# Altium schematic sheet 02-Power.SchDoc
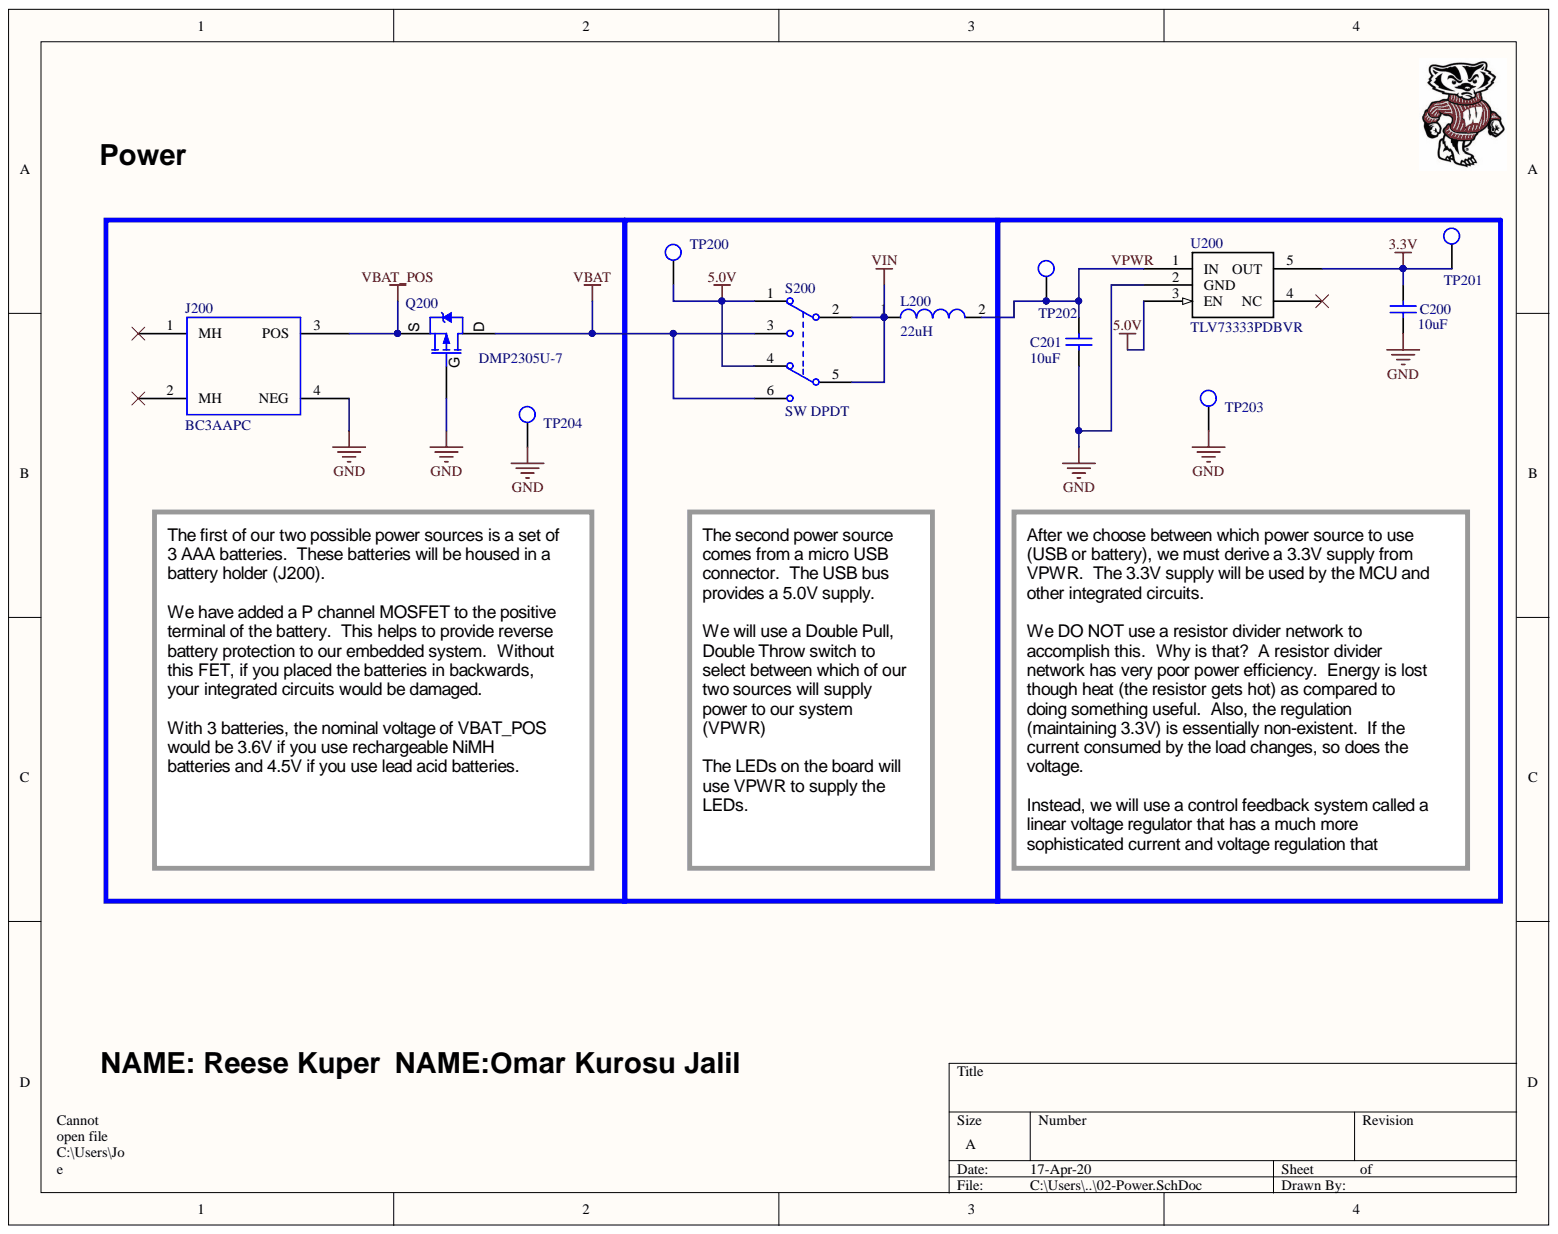
[diagram p0: 02-Power.SchDoc]

## Parts
C200  10uF  [CAP_0805]
C201  10uF  [CAP_0805]
J200  BC3AAPC  [BC3AAPC]
L200  Inductance: 22uH  [SRN3015]  (hidden comment: SRN3015-220M)
Q200  Manufacturer Part Number: DMP2305U-7  [SOT23]  (hidden comment: PMOS-DMP2305U-7)
S200  SW DPDT  [DPDT]
TP200  TP_1206  [TP-SMC-1206]  (value not drawn)
TP201  TP_1206  [TP-SMC-1206]  (value not drawn)
TP202  TP_1206  [TP-SMC-1206]  (value not drawn)
TP203  TP_1206  [TP-SMC-1206]  (value not drawn)
TP204  TP_1206  [TP-SMC-1206]  (value not drawn)
U200  Manufacturer Part Number: TLV73333PDBVR  [IC_TLV73333]  (hidden comment: IC-TLV733P)

## Nets
3.3V: C200.2 TP201.1 U200.5(OUT)
5.0V: S200.1 S200.4 TP200.1 U200.3(EN)
GND: C200.1 C201.1 J200.4(NEG) Q200.1 TP203.1 TP204.1 U200.2(GND)
VBAT: Q200.3 S200.3 S200.6
VBAT_POS: J200.3(POS) Q200.2
VIN: L200.1 S200.2 S200.5
VPWR: C201.2 L200.2 TP202.1 U200.1(IN)

Not connected: J200 1(MH) 2(MH); U200 4(NC)

## Library data of the parts
C200 C201 = CAP_0805_U_010.00: CAP CER 10UF 16V X7R 0805; Manufacturer: Samsung; Manufacturer Part Number: CL21A106KPCLQNC; Dielectric: X5R; Tolerance: 10%; Voltage Rating: 10V; Capacitance: 10uF; Case/Package: 0805; Case Code (Imperial): 0805; Case Code (Metric): 2012; Component Kind: Standard; ComponentLink1Description: https://datasheet.ciiva.com/25730/cl21a106kpclqnc-25730594.pdf; ComponentLink1URL: https://datasheet.ciiva.com/25730/cl21a106kpclqnc-25730594.pdf; ComponentLink3Description: https://datasheet.ciiva.com/26920/getdatasheetpartid-355170-26920201.pdf; ComponentLink3URL: https://datasheet.ciiva.com/26920/getdatasheetpartid-355170-26920201.pdf; Contact Plating: Tin; Depth: 1.25mm; Height: 0.85mm; Length: 2mm; Library Name: ece315-S20.SCHLIB; Max Operating Temperature: 85°C; Min Operating Temperature: -55°C; Mount: Surface Mount; Package Quantity: 1; Packaging: Tape and Reel; Pricing 1: 1=0.22, 10=0.148, 100=0.0749, 500=0.05122, 1000=0.04531 (USD); Radiation Hardening: No; Stock 1: 40000; Supplier 1: Digi-Key; Supplier Part Number 1: 1276-2402-1-ND; Thickness: 0.037inch; Width: 0.049inch
J200 = BC3AAPC: BATTERY HOLDER AA 3 CELL PC PIN; Manufacturer: Memory Protection Devices; Number of Cells: 3; Component Kind: Standard; ComponentLink1Description: http://www.memoryprotectiondevices.com/datasheets/BC3AAPC-datasheet.pdf?src-supplier=Digi-Key; ComponentLink1URL: http://www.memoryprotectiondevices.com/datasheets/BC3AAPC-datasheet.pdf?src-supplier=Digi-Key; Library Name: Battery.SchLib; Manufacturer Part Number: BC3AAPC; Max Operating Temperature: 100°C; Min Operating Temperature: -10°C; Mount: Through Hole,PCB; Package Quantity: 100; Supplier: Digi-Key; Supplier Part Number: BC3AAPC-ND; Termination: PC Pin
L200 = SRN3015-220M: 22uH 3x3mm sheilded inductor 600mA; Manufacturer 1: Bourns; Core Material: Ferrite; DC Resistance (DCR): 622mR; Inductance Tolerance: 20%; Saturation Current: 580mA; Self Resonant Frequency: 28MHz; Shielding: Shielded; Test Frequency: 1MHz; Case/Package: 1212; Component Kind: Standard; ComponentLink1Description: https://datasheet.ciiva.com/11802/0900766b80fe1f40-11802602.pdf?src-supplier=RSComponents; ComponentLink1URL: https://datasheet.ciiva.com/11802/0900766b80fe1f40-11802602.pdf?src-supplier=RSComponents; ComponentLink2Description: https://datasheet.ciiva.com/1048/srn3015-1048848.pdf?src-supplier=Digi-Key; ComponentLink2URL: https://datasheet.ciiva.com/1048/srn3015-1048848.pdf?src-supplier=Digi-Key; ComponentLink3Description: https://datasheet.ciiva.com/1048/srn3015-1048849.pdf?src-supplier=Digi-Key; ComponentLink3URL: https://datasheet.ciiva.com/1048/srn3015-1048849.pdf?src-supplier=Digi-Key; ComponentLink4Description: https://datasheet.ciiva.com/1048/srn3015-1048850.pdf?src-supplier=Digi-Key; ComponentLink4URL: https://datasheet.ciiva.com/1048/srn3015-1048850.pdf?src-supplier=Digi-Key; ComponentLink5Description: https://datasheet.ciiva.com/30089/587srn3015-30089590.pdf?src-supplier=Onlinecomponents.com; ComponentLink5URL: https://datasheet.ciiva.com/30089/587srn3015-30089590.pdf?src-supplier=Onlinecomponents.com; ComponentLink6Description: https://datasheet.ciiva.com/7849/1481208-7849511.pdf?src-supplier=Element14; ComponentLink6URL: https://datasheet.ciiva.com/7849/1481208-7849511.pdf?src-supplier=Element14; ComponentLink7Description: https://datasheet.ciiva.com/22678/1875284-22678991.pdf?src-supplier=Element14; ComponentLink7URL: https://datasheet.ciiva.com/22678/1875284-22678991.pdf?src-supplier=Element14; Composition: Wirewound; Contact Plating: Tin; Current Rating: 600mA; DC Current: 600mA; Depth: 3.1mm; Height: 1.5mm; Height - Seated (Max): 0.059inch; Length: 3.1mm; Library Name: Inductors.SchLib; Manufacturer Part Number 1: SRN3015-220M; Max DC Current: 600mA; Max Operating Temperature: 125°C; Military Standard: Not; Min Operating Temperature: -40°C; Number of Pins: 2; Package Quantity: 2000; Packaging: Tape and Reel; Pricing 1: 1=0.46000, 10=0.40300, 100=0.28750, 500=0.24150, 1000=0.19550 (USD); REACH SVHC: No SVHC; RMS Current (Irms): 600mA; RoHS: Yes; Stock 1: 46536; Supplier 1: Digi-Key; Supplier Part Number 1: SRN3015-220MCT-ND; Termination: SMD/SMT; Tolerance: 20%; Weight: 0.001235oz; Width: 0.118inch
Q200 = PMOS-DMP2305U-7: MOSFET P-CH 20V 4.2A SOT-23; Manufacturer: Diodes Zetex; Drain to Source Breakdown Voltage: -20V; Drain to Source Resistance: 60mR; Element Configuration: Single; Fall Time: 13ns; Gate to Source Voltage (Vgs): 8V; Input Capacitance: 727pF; Max Power Dissipation: 1.4W; Number of Channels: 1; Threshold Voltage: -500mV; Turn-Off Delay Time: 53.8ns; Turn-On Delay Time: 14ns; Case/Package: SOT-23; Component Kind: Standard; ComponentLink1Description: Manufacturer Link; ComponentLink1URL: http://www.irf.com/; ComponentLink2Description: http://www.diodes.com/_files/datasheets/ds30149.pdf?src-supplier=Future+Electronics; ComponentLink2URL: http://www.diodes.com/_files/datasheets/ds30149.pdf?src-supplier=Future+Electronics; ComponentLink3Description: http://www.diodes.com/datasheets/ds31737.pdf?src-supplier=Avnet+Asia; ComponentLink3URL: http://www.diodes.com/datasheets/ds31737.pdf?src-supplier=Avnet+Asia; Contact Plating: Tin,Matte; Continuous Drain Current (ID): 4.2A; Drain to Source Voltage (Vdss): 20V; Height: 1mm; Lead Free: Lead Free; Length: 2.9mm; Library Name: Transistors.SchLib; Max Operating Temperature: 150°C; Min Operating Temperature: -55°C; Mount: Surface Mount; Number of Elements: 1; Number of Pins: 3; On-State Resistance: 60mR; Package Quantity: 3000; Packaging: Tape and Reel; Power Dissipation: 1.4W; Radiation Hardening: No; Rds On Max: 60mR; REACH SVHC: No SVHC; Rise Time: 13ns; Supplier: Digi-Key; Supplier Part Number: DMP2305UDICT-ND; Weight: 0.000282oz; Width: 1.3mm
S200 = SW DPDT: Switch; Manufacturer: ITT /  C&K Components; Manufacturer Part Number: JS202011SCQN; Actuator Color: Black; Actuator Material: Nylon 4/6; Actuator Style: Top Slide; Body Orientation: Straight; Contact Rating @ Voltage: 0.3@6VDC A; COO: US; Dielectric Strength: 500 VAC; Electrical Life: 15000 Cycles; Maximum Contact Resistance: 70 mOhm; Maximum DC Voltage Rating: 6 V; Minimum Insulation Resistance: 100 MOhm; Base Material: N/A; Code_IPC: SOT26A-6; Code_JEITA: SC-74; Component Kind: Standard; ComponentLink1Description: https://datasheet.ciiva.com/23829/1707718-23829488.pdf; ComponentLink1URL: https://datasheet.ciiva.com/23829/1707718-23829488.pdf; ComponentLink2Description: https://datasheet.ciiva.com/2889/js-29aug13-2889127.pdf; ComponentLink2URL: https://datasheet.ciiva.com/2889/js-29aug13-2889127.pdf; ComponentLink3Description: https://datasheet.ciiva.com/2889/js-15sept15-2889128.pdf; ComponentLink3URL: https://datasheet.ciiva.com/2889/js-15sept15-2889128.pdf; ComponentLink4Description: https://datasheet.ciiva.com/2889/js-15sept15-2889129.pdf; ComponentLink4URL: https://datasheet.ciiva.com/2889/js-15sept15-2889129.pdf; ComponentLink5Description: https://datasheet.ciiva.com/22630/1707718-22630697.pdf; ComponentLink5URL: https://datasheet.ciiva.com/22630/1707718-22630697.pdf; ComponentLink6Description: https://datasheet.ciiva.com/8625/js-9dec14-8625470.pdf; ComponentLink6URL: https://datasheet.ciiva.com/8625/js-9dec14-8625470.pdf; Contact Material: Copper Alloy; Contact Plating: Silver; Dimension: 9 x 7.5 x 5.5 mm; ECCN: EAR99; Family: JS; Housing Material: Nylon 4/6; HTS: 8536509050; LatestRevisionDate: 29-May-2009; LatestRevisionNote: IPC-7351 Footprint Added.; Maximum AC Voltage Rating: N/A; Mechanical Life: N/A; Mounting: Surface Mount,Gull Wing; Operating Temperature: -40 to 85 C; PackageDescription: 6-Pin SOT-23 Package 0.95 mm Pitch, 2.7 mm Lead Span; PackageReference: SOT23-6; PackageVersion: Mar-2001; Packaging: Tape and Reel; Part No: JS202011SCQN; Pole Throw Configuration: DPDT; Pricing 1: 1=0.46, 10=0.441, 25=0.4316, 50=0.3858, 100=0.3674, 250=0.3582, 500=0.3031 (USD); Product Weight: N/A; Published: 24-Mar-1999; RoHS: Yes; Seal Description: N/A; Seal Location: N/A; Stock 1: 22661; Supplier 1: C&K Components; Supplier Part Number 1: 401-2002-1-ND; Supplier Standard Pack: 5,000; Switch Configuration: N/A; Type: Sub-Miniature; Library Name: ece315-2018-fall.SchLib; Publisher: Altium Limited
TP200-TP204 = TP_1206: Test Point SMD 1206; Manufacturer: TE Connectivity (Tyco Electronics); Manufacturer Part Number: 1625854-1; Power Rating: N/R; Resistance Value: 0 Ohm; Case Size: 1206; Component Kind: Standard; ComponentLink1Description: https://datasheet.ciiva.com/6439/eng-ds-1773266-a-6439169.pdf; ComponentLink1URL: https://datasheet.ciiva.com/6439/eng-ds-1773266-a-6439169.pdf; ComponentLink2Description: https://datasheet.ciiva.com/30136/1773266-a-30136341.pdf; ComponentLink2URL: https://datasheet.ciiva.com/30136/1773266-a-30136341.pdf; ComponentLink3Description: https://datasheet.ciiva.com/6439/eng-ds-1773266-a-6439080.pdf; ComponentLink3URL: https://datasheet.ciiva.com/6439/eng-ds-1773266-a-6439080.pdf; ComponentLink4Description: https://datasheet.ciiva.com/6439/eng-ds-1773266-a-6439081.pdf; ComponentLink4URL: https://datasheet.ciiva.com/6439/eng-ds-1773266-a-6439081.pdf; ComponentLink5Description: https://datasheet.ciiva.com/6439/eng-ds-1773266-a-6439083.pdf; ComponentLink5URL: https://datasheet.ciiva.com/6439/eng-ds-1773266-a-6439083.pdf; Dimension: 3.2 x 1.6 x 1.25mm; Family: RC; Library Name: ece315-S20.SCHLIB; Mounting: Surface Mount; Operating Temperature: -55 to 125 C; Packaging: Tape and Reel; Part No: 1625854-1; Pricing 1: 1=0.28, 10=0.261, 25=0.224, 50=0.1902, 100=0.1828, 250=0.1641, 500=0.1567, 1000=0.1306 (USD); RoHS: Yes; Stock 1: 31937; Supplier 1: Digi-Key; Supplier Part Number 1: A106143CT-ND; Temperature Coefficient: N/R; Terminal Pitch: N/R; Termination Style: N/R; Tolerance: N/R
U200 = IC-TLV733P: IC REG LINEAR 3.3V 300MA SOT23-5; Manufacturer: Texas Instruments; Accuracy: 1%; Dropout Voltage: 125mV; Max Input Voltage: 5.5V; Min Current Limit: 360mA; Min Input Voltage: 1.4V; Number of Outputs: 1; Polarity: Positive; Quiescent Current: 60uA; Case/Package: SOT-23-5; Component Kind: Standard; ComponentLink1Description: https://datasheet.ciiva.com/48677/tlv733p-48677542.pdf; ComponentLink1URL: https://datasheet.ciiva.com/48677/tlv733p-48677542.pdf; Contact Plating: Nickel,Gold; Lead Free: Lead Free; Library Name: ece315-S20.SCHLIB; Max Operating Temperature: 125°C; Max Output Current: 300mA; Min Operating Temperature: -40°C; Mount: Surface Mount; Number of Pins: 5; Number of Regulators: 1; Output Current: 300mA; Output Voltage: 3.3V; Package Quantity: 3000; Packaging: Tape and Reel; Pricing 1: 1=0.46, 10=0.373, 100=0.2538, 500=0.19034, 1000=0.14276 (USD); Radiation Hardening: No; Stock 1: 44968; Supplier 1: Digi-Key; Supplier Part Number 1: 296-40673-1-ND; Weight: 0.000557oz
